# Altium schematic sheet Analog.SchDoc
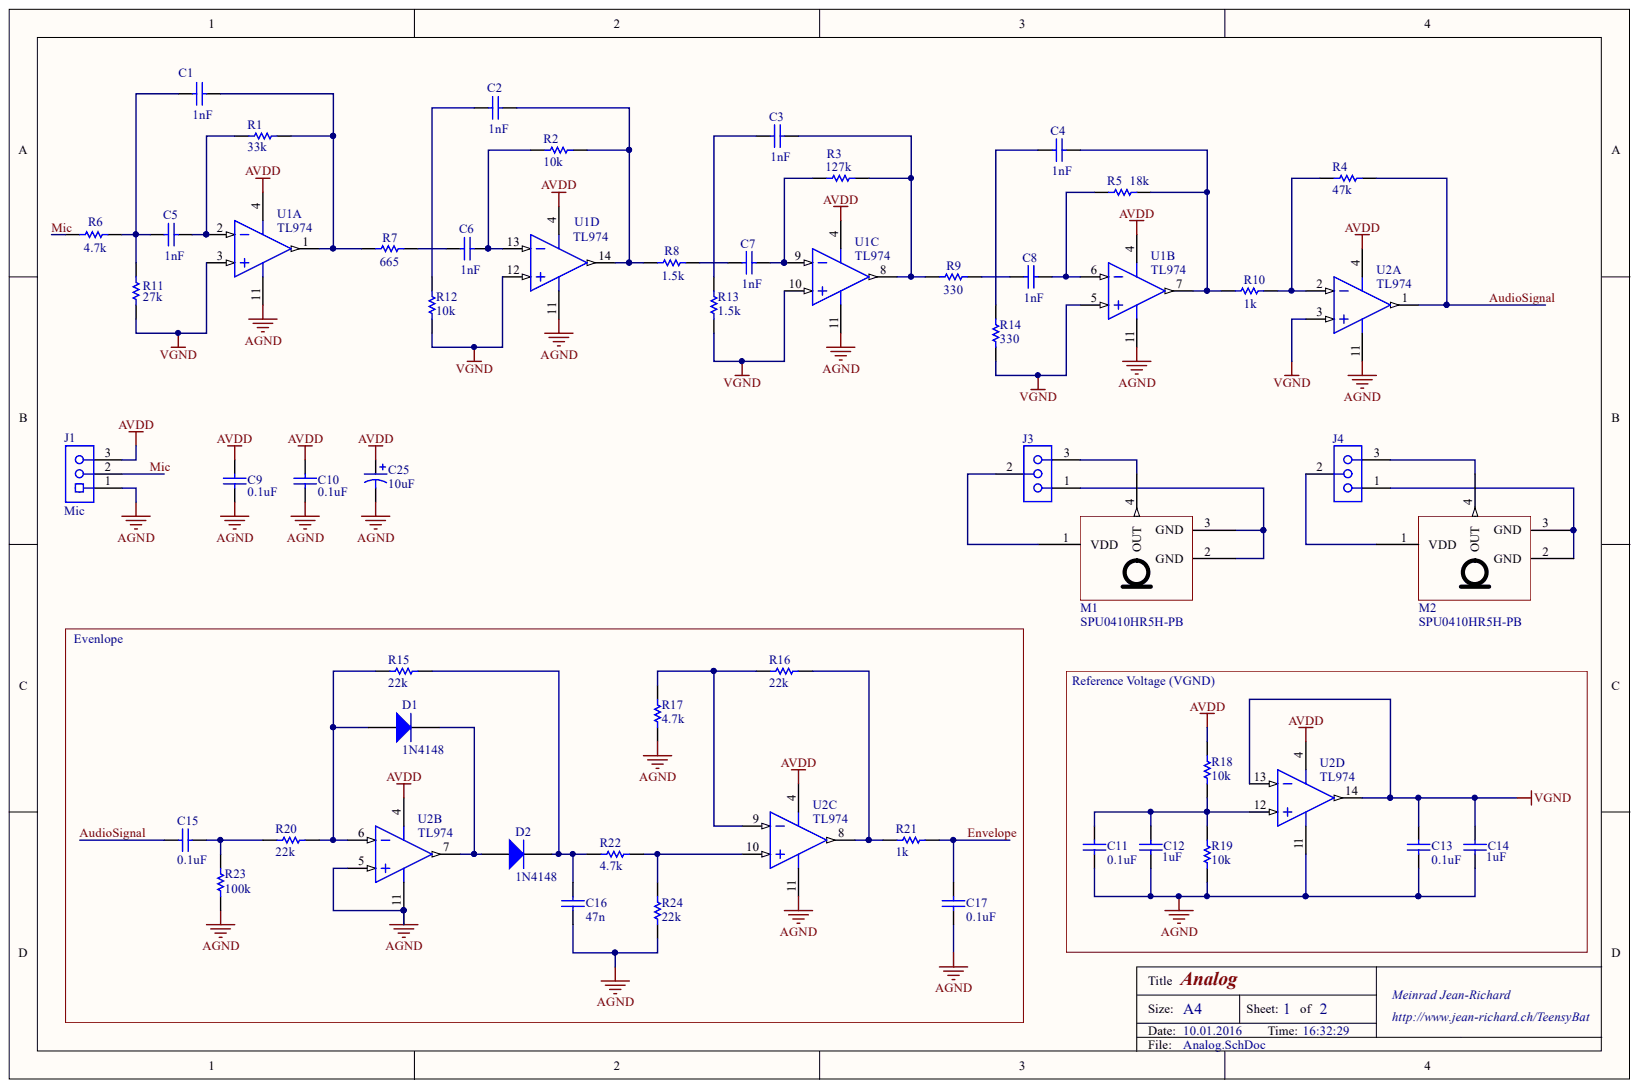
[diagram p0: Analog.SchDoc]

## Parts
C1  Capacitance: 1nF  [CAPC3216X94X50ML20T25]  (hidden comment: AVX Interconnect / Elco 12063A102JAT2A)
C2  Capacitance: 1nF  [CAPC3216X94X50ML20T25]  (hidden comment: AVX Interconnect / Elco 12063A102JAT2A)
C3  Capacitance: 1nF  [CAPC3216X94X50ML20T25]  (hidden comment: AVX Interconnect / Elco 12063A102JAT2A)
C4  Capacitance: 1nF  [CAPC3216X94X50ML20T25]  (hidden comment: AVX Interconnect / Elco 12063A102JAT2A)
C5  Capacitance: 1nF  [CAPC3216X94X50ML20T25]  (hidden comment: AVX Interconnect / Elco 12063A102JAT2A)
C6  Capacitance: 1nF  [CAPC3216X94X50ML20T25]  (hidden comment: AVX Interconnect / Elco 12063A102JAT2A)
C7  Capacitance: 1nF  [CAPC3216X94X50ML20T25]  (hidden comment: AVX Interconnect / Elco 12063A102JAT2A)
C8  Capacitance: 1nF  [CAPC3216X94X50ML20T25]  (hidden comment: AVX Interconnect / Elco 12063A102JAT2A)
C9  Capacitance: 0.1uF  [CAPC3216X94X50ML20T25]  (hidden comment: AVX Interconnect / Elco 12065C104KAT2A)
C10  Capacitance: 0.1uF  [CAPC3216X94X50ML20T25]  (hidden comment: AVX Interconnect / Elco 12065C104KAT2A)
C11  Capacitance: 0.1uF  [CAPC3216X94X50ML20T25]  (hidden comment: AVX Interconnect / Elco 12065C104KAT2A)
C12  Capacitance: 1uF  [CAPC3216X178X50ML20T25]  (hidden comment: AVX Interconnect / Elco 12065C105KAT2A)
C13  Capacitance: 0.1uF  [CAPC3216X94X50ML20T25]  (hidden comment: AVX Interconnect / Elco 12065C104KAT2A)
C14  Capacitance: 1uF  [CAPC3216X178X50ML20T25]  (hidden comment: AVX Interconnect / Elco 12065C105KAT2A)
C15  Capacitance: 0.1uF  [CAPC3216X94X50ML20T25]  (hidden comment: AVX Interconnect / Elco 12065C104KAT2A)
C16  47n  [CAPC3216X94X50ML20T25]
C17  Capacitance: 0.1uF  [CAPC3216X94X50ML20T25]  (hidden comment: AVX Interconnect / Elco 12065C104KAT2A)
C25  10uF  [PCB-w1mz1f6kibge3ckcia7t-1]
D1  1N4148  [DIOD-1N4148WS-SOD-323-2]
D2  1N4148  [DIOD-1N4148WS-SOD-323-2]
J1  Mic  [PCB-tsoe1m70tj06s3chv47k-1]
J3  TE Connectivity 825433-3  [PCB-43x6b8o6d5mxbq35c0sh-1]  (value not drawn)
J4  TE Connectivity 825433-3  [PCB-43x6b8o6d5mxbq35c0sh-1]  (value not drawn)
M1  SPU0410HR5H-PB  [Knowles-SPU0410HR5H]
M2  SPU0410HR5H-PB  [Knowles-SPU0410HR5H]
R1  Resistance: 33k  [RESC3216X70X50NL05T20]  (hidden comment: Panasonic ERJ-8ENF3302V)
R2  10k  [RESC3216X70X50NL05T20]
R3  Resistance: 127k  [RESC3216X70X50NL05T20]  (hidden comment: Panasonic ERJ-8ENF1273V)
R4  47k  [RESC3216X70X50NL05T20]
R5  Resistance: 18k  [RESC3216X70X50NL05T20]  (hidden comment: Panasonic ERJ-8ENF1802V)
R6  Resistance: 4.7k  [RESC3216X70X50NL05T20]  (hidden comment: Panasonic ERJ-8ENF4701V)
R7  Panasonic ERJ-8ENF6650V  [RESC3216X70X50NL05T20]  (value not drawn)
R8  Resistance: 1.5k  [RESC3216X70X50NL05T20]  (hidden comment: Panasonic ERJ-8ENF1501V)
R9  Panasonic ERJ-8ENF3300V  [RESC3216X70X50NL05T20]  (value not drawn)
R10  1k  [RESC3216X70X50NL05T20]
R11  Resistance: 27k  [RESC3216X70X50NL05T20]  (hidden comment: Panasonic ERJ8ENF2702V)
R12  10k  [RESC3216X70X50NL05T20]
R13  Resistance: 1.5k  [RESC3216X70X50NL05T20]  (hidden comment: Panasonic ERJ-8ENF1501V)
R14  Panasonic ERJ-8ENF3300V  [RESC3216X70X50NL05T20]  (value not drawn)
R15  22k  [RESC3216X70X50NL05T20]
R16  22k  [RESC3216X70X50NL05T20]
R17  4.7k  [RESC3216X70X50NL05T20]
R18  10k  [RESC3216X70X50NL05T20]
R19  10k  [RESC3216X70X50NL05T20]
R20  22k  [RESC3216X70X50NL05T20]
R21  1k  [RESC3216X70X50NL05T20]
R22  4.7k  [RESC3216X70X50NL05T20]
R23  100k  [RESC3216X70X50NL05T20]
R24  22k  [RESC3216X70X50NL05T20]
U1  TL974  [TI-D14_N]  (parts A,B,C,D)
U2  TL974  [TI-D14_N]  (parts A,B,C,D)

## Nets
AGND: C9.1 C10.1 C11.1 C12.1 C13.1 C14.1 C16.1 C17.1 C25.2 J1.1 R17.1 R19.2 R23.1 R24.1 U1.11 U2.5 U2.11
AVDD: C9.2 C10.2 C25.1 J1.3 R18.1 U1.4 U2.4
VGND: C13.2 C14.2 R11.1 R12.2 R13.1 R14.1 U1.3 U1.5 U1.10 U1.12(1nF) U2.3 U2.13 U2.14
AUDIOSIGNAL: C15.2 R4.2 U2.1
ENVELOPE: C17.2 R21.2
MIC: J1.2 R6.1
NetC1_1: C1.1 C5.1 R6.2 R11.2
NetC1_2: C1.2 R1.1 R7.1 U1.1
NetC2_1: C2.1 R12.1
NetC2_2: C2.2 R2.1 R8.1 U1.14
NetC3_1: C3.1 R13.2
NetC3_2: C3.2 R3.2 R9.1 U1.8
NetC4_1: C4.1 R14.2
NetC4_2: C4.2 R5.2 R10.1 U1.7
NetC5_2: C5.2 R1.2 U1.2
NetC6_1: C6.1 R7.2
NetC6_2: C6.2 R2.2 U1.13
NetC7_1: C7.1 R8.2
NetC7_2: C7.2 R3.1 U1.9
NetC8_1: C8.1 R9.2
NetC8_2: C8.2 R5.1 U1.6
NetC11_2: C11.2 C12.2 R18.2 R19.1 U2.12
NetC15_1: C15.1 R20.1 R23.2
NetC16_2: C16.2 D2.1 R15.2 R22.2
NetD1_1: D1.1 D2.2 U2.7
NetD1_2: D1.2 R15.1 R20.2 U2.6
NetJ3_1: J3.1 M1.2(GND) M1.3(GND)
NetJ3_2: J3.2 M1.1(VDD)
NetJ3_3: J3.3 M1.4(OUT)
NetJ4_1: J4.1 M2.2(GND) M2.3(GND)
NetJ4_2: J4.2 M2.1(VDD)
NetJ4_3: J4.3 M2.4(OUT)
NetR4_1: R4.1 R10.2 U2.2
NetR16_1: R16.1 R17.2 U2.9
NetR16_2: R16.2 R21.1 U2.8
NetR22_1: R22.1 R24.2 U2.10

## Library data of the parts
C1-C8 = CMP-173154-2: CAP CER 1000PF 25V 5% NP0 1206; Case/Package (SI): 3216; Dielectric Characteristic: C0G/NP0; Lead-Free Status: Lead Free; Voltage Rating (DC): 25.0 V; Capacitance Tolerance: ±5%; Case/Package: 1206; Component Kind: Standard; Library Name: CommunityVault; Mounting Style: Surface Mount; Number of Pins: 2; OctopartID: ed7b24f28dc832bc; Octopart Url: https://octopart.com/12063a102jat2a-avx+interconnect+%2F+elco-8603093; Packaging: Tape; RoHS: Compliant
C9-C11 C13 C15 C17 = CMP-126010-2: CAP CER 0.1UF 50V 10% X7R 1206; Case/Package (SI): 3216; Dielectric Material: Ceramic; Insulation Resistance: 1e+11 Ω; Lead-Free Status: Lead Free; Voltage Rating (DC): 50.0 V; Capacitance Tolerance: ±10%; Case/Package: 1206; Component Kind: Standard; Dielectric Characteristic: X7R; Library Name: CommunityVault; Mounting Style: Surface Mount; Number of Pins: 2; OctopartID: 839348c5bc2c5c54; Octopart Url: https://octopart.com/12065c104kat2a-avx+interconnect+%2F+elco-39590617; REACH SVHC Compliance: No SVHC; RoHS: Compliant; Size-Height: 0.00094 m; Size-Length: 0.0032004 m; Size-Thickness: 0.0009398 m; Size-Width: 0.0016002 m
C12 C14 = CMP-127284-2: CAP CER 1UF 50V 10% X7R 1206; Case/Package (SI): 3216; Dielectric Material: Ceramic Multilayer; Lead-Free Status: Lead Free; Voltage Rating (DC): 50.0 V; Capacitance Tolerance: ±10%; Case/Package: 1206; Component Kind: Standard; Dielectric Characteristic: X7R; Library Name: CommunityVault; Mounting Style: Surface Mount; Number of Pins: 2; OctopartID: 506d991562b08faa; Octopart Url: https://octopart.com/12065c105kat2a-avx+interconnect+%2F+elco-7477179; REACH SVHC Compliance: No SVHC; RoHS: Compliant
C16 = CMP-126512-2: CAP CER 0.047UF 50V 10% X7R 1206; Capacitance: 4.7e-08 F; Case/Package (SI): 3216; Dielectric Material: Ceramic Multilayer; Lead-Free Status: Lead Free; Voltage Rating (DC): 50.0 V; Capacitance Tolerance: ±10%; Case/Package: 1206; Component Kind: Standard; Dielectric Characteristic: X7R; Library Name: CommunityVault; Mounting Style: Surface Mount; Number of Pins: 2; OctopartID: 472a8c7d27a098ed; Octopart Url: https://octopart.com/12065c473kat2a-avx+interconnect+%2F+elco-39667180; REACH SVHC Compliance: No SVHC; RoHS: Compliant; Size-Height: 0.00094 m; Size-Length: 0.0032 m; Size-Width: 0.0016 m
C25 = CMP-295de054142df69a-1: 5mm x 11mm l/s=2.0mm 105deg 10uf 16v Radial Leads Lesr Aluminum Electrolytic Cap; Manufacturer Part Number: UPW1C100MDD; Capacitance: 1e-05 F; Capacitance Tolerance: ±20%; Equivalent Series Resistance (ESR): 1.2 Ω; Lead-Free Status: Lead Free; Voltage Rating (DC): 16.0 V; Component Kind: Standard; Dielectric Material: Aluminum Electrolytic; Library Name: CommunityVault; Mounting Style: Through Hole; Number of Pins: 2; OctopartID: 295de054142df69a; Octopart URL: http://octopart.com/upw1c100mdd-nichicon-202774; Packaging: Bulk; RoHS: Compliant; Size-Diameter: 0.005 m; Size-Height: 0.011 m; Size-Length: 0.011 m
D1 D2 = CMP-815266-2: DIODE SWITCHING 75V 0.15A SOD323; Capacitance: 4e-12 F; Current Rating: 0.2 A; Lead-Free Status: Lead Free; Polarity: Standard; Power Rating: 0.2 W; Voltage Rating (DC): 100.0 V; Case/Package: DO-35; Component Kind: Standard; Library Name: CommunityVault; Lifecycle Status: Active; Mounting Style: Through Hole; Number of Pins: 2; OctopartID: ae03670d61fd71c4; Octopart Url: https://octopart.com/1n4148-fairchild+semiconductor-322200; REACH SVHC Compliance: No SVHC; RoHS: Compliant
J1 = CMP-61f1a8aabfaebe36-2: M20 Socket Sil Vertical 3W 2.54Mm; Manufacturer Part Number: M20-7820346; Contact Resistance: 0.015 Ω; Current Rating: 3.0 A; Gender: Female; Insulation Resistance: 1000000.0 Ω; Number of Contacts: 3; Number of Mating Cycles: 50; Pin Pitch: 0.00254 m; Component Kind: Standard; Contact Material: Phosphor Bronze; Contact Plating: Tin; Library Name: CommunityVault; Number of Rows: 1; OctopartID: 61f1a8aabfaebe36; Octopart Url: http://octopart.com/m20-7820346-harwin-5372729; REACH SVHC Compliance: No SVHC; RoHS: Compliant; Seller Landing  Url: https://octopart-clicks.com/click/track?country=US&ak=8157f166&sig=097bad3&sid=2402&ppid=5372729&vpid=6626761&ct=offers
J3 J4 = CMP-52d299a8d8748d15-2: Pin header; pin strips; AMPMODU MOD II; male; PIN: 3; straight; Current Rating: 3.0 A; ELV Compliant: Compliant; Number of Contacts: 3; Pin Pitch: 0.00254 m; Component Kind: Standard; Contact Material: Brass; Contact Plating: Gold, Gold over Nickel, Tin, Tin over Nickel; Flammability Rating: UL 94 V-0; Gender: Male; Housing Color: Green; Housing Material: Polyester; Library Name: CommunityVault; Lifecycle Status: Active; Manufacturer Part Number: 825433-3; Mounting Style: PCB; OctopartID: 52d299a8d8748d15; Octopart URL: http://octopart.com/825433-3-te+connectivity-40939456; Orientation: Vertical; Packaging: Box; REACH SVHC Compliance: No SVHC; RoHS: Compliant
M1 M2 = CMP-554d9d6504ba8a8a-4: Mic Mems Analog Omni -42db; Supply Voltage (DC): V; Component Kind: Standard; Library Name: CommunityVault; Manufacturer Part Number: SPU0410HR5H-PB; OctopartID: 554d9d6504ba8a8a; Octopart URL: http://octopart.com/spu0410hr5h-pb-knowles+acoustics-19035281; RoHS: Compliant
R1 = CMP-3d14f753aa60e2d3-1: Res Thick Film 1206 33K Ohm 1% 1/4W ±100ppm/°C Molded SMD SMD Punched Carrier T/R; Power Rating: 0.25 W; Voltage Rating (DC): 200.0 V; Case/Package: 1206; Component Kind: Standard; Library Name: CommunityVault; Lifecycle Status: Not Listed by Manufacturer; Manufacturer Part Number: ERJ-8ENF3302V; Mounting Style: Surface Mount; Number of Pins: 2; OctopartID: 3d14f753aa60e2d3; Octopart URL: http://octopart.com/erj-8enf3302v-panasonic-55423506; Packaging: Tape & Reel (TR), Cut Tape (CT); REACH SVHC Compliance: No SVHC; Resistance Tolerance: ±1%; RoHS: Compliant
R2 R12 R18 R19 = CMP-3663449-2: Thick Film Resistors - SMD 1206 10Kohms 1% Tol; Case/Package (SI): 3216; Lead-Free Status: Lead Free; Power Rating: 0.25 W; Resistance: 10000.0 Ω; Voltage Rating (DC): 200.0 V; Case/Package: 1206; Component Kind: Standard; Library Name: CommunityVault; Lifecycle Status: Not Listed by Manufacturer; Mounting Style: Surface Mount; Number of Pins: 2; OctopartID: 5d4197061c908286; Octopart Url: https://octopart.com/erj-8enf1002v-panasonic-55403703; REACH SVHC Compliance: No SVHC; Resistance Tolerance: ±1%; RoHS: Compliant; Size-Height: 0.0006 m; Size-Length: 0.002 m; Size-Width: 0.0016 m
R3 = CMP-3679732-2: Thick Film Resistors 1206 127Kohms 1% Tolerance; Case/Package (SI): 3216; Power Rating: 0.25 W; Voltage Rating (DC): 200.0 V; Case/Package: 1206; Component Kind: Standard; Library Name: CommunityVault; Lifecycle Status: Not Listed by Manufacturer; Mounting Style: Surface Mount; OctopartID: 2e2ce46bba5af1eb; Octopart Url: https://octopart.com/erj-8enf1273v-panasonic-55423248; REACH SVHC Compliance: No SVHC; Resistance Tolerance: ±1%; RoHS: Compliant; Size-Height: 0.0006 m; Size-Length: 0.002 m; Size-Width: 0.0016 m
R4 = CMP-8a94435db0625553-1: Res Thick Film 1206 47K Ohm 1% 0.25W(1/4W) ±100ppm/C Molded SMD Automotive Punched T/R; Manufacturer Part Number: ERJ-8ENF4702V; Lead-Free Status: Lead Free; Resistance: 47000.0 Ω; Voltage Rating (DC): 200.0 V; Case/Package: 1206; Component Kind: Standard; Library Name: CommunityVault; Lifecycle Status: Not Listed by Manufacturer; Mounting Style: Surface Mount; Number of Pins: 2; OctopartID: 8a94435db0625553; Octopart URL: http://octopart.com/erj-8enf4702v-panasonic-12787600; Packaging: Tape & Reel (TR), Cut Tape (CT); Power Rating: 0.25 W; REACH SVHC Compliance: No SVHC; Resistance Tolerance: ±1%; RoHS: Compliant
R5 = CMP-8a3a407d1e2d55fd-1: RES, THICK FILM, 18K, 250mW, 1%, 1206, RES, THICK FILM, 18K, 250mW, 1%, 1206, FULL REEL; Power Rating: 0.25 W; Voltage Rating (DC): 200.0 V; Case/Package: 1206; Component Kind: Standard; Library Name: CommunityVault; Lifecycle Status: Not Listed by Manufacturer; Manufacturer Part Number: ERJ-8ENF1802V; Mounting Style: Surface Mount; Number of Pins: 2; OctopartID: 8a3a407d1e2d55fd; Octopart URL: http://octopart.com/erj-8enf1802v-panasonic-55423345; Packaging: Tape & Reel (TR), Cut Tape (CT); REACH SVHC Compliance: No SVHC; Resistance Tolerance: ±1%; RoHS: Compliant
R6 = CMP-e840219124d23787-1: Res Thick Film 1206 4.7K Ohm 1% 0.25W(1/4W) ±100ppm/C Molded SMD Automotive Punched T/R; Lead-Free Status: Lead Free; Voltage Rating (DC): 200.0 V; Case/Package: 1206; Component Kind: Standard; Library Name: CommunityVault; Lifecycle Status: Not Listed by Manufacturer; Manufacturer Part Number: ERJ-8ENF4701V; Mounting Style: Surface Mount; Number of Pins: 2; OctopartID: e840219124d23787; Octopart URL: http://octopart.com/erj-8enf4701v-panasonic-14412276; Packaging: Tape & Reel (TR), Cut Tape (CT); Power Rating: 0.25 W; REACH SVHC Compliance: No SVHC; Resistance Tolerance: ±1%; RoHS: Compliant
R7 = CMP-3676928-2: Thick Film Resistors - SMD 1206 665ohms 1% Tolerance; Case/Package (SI): 3216; Lead-Free Status: Contains Lead; Power Rating: 0.25 W; Resistance: 665; Voltage Rating (DC): 200.0 V; Case/Package: 1206; Component Kind: Standard; Library Name: CommunityVault; Lifecycle Status: Not Listed by Manufacturer; Mounting Style: Surface Mount; OctopartID: 11b5dbf2860ace72; Octopart Url: https://octopart.com/erj-8enf6650v-panasonic-55423705; REACH SVHC Compliance: No SVHC; Resistance Tolerance: ±1%; RoHS: Compliant; Size-Height: 0.0006 m; Size-Length: 0.002 m; Size-Width: 0.0016 m
R8 R13 = CMP-3653427-2: Thick Film Resistors - SMD 1206 1.5Kohms 1% Tol; Case/Package (SI): 3216; Lead-Free Status: Lead Free; Power Rating: 0.25 W; Voltage Rating (DC): 200.0 V; Case/Package: 1206; Component Kind: Standard; Library Name: CommunityVault; Lifecycle Status: Not Listed by Manufacturer; Mounting Style: Surface Mount; Number of Pins: 2; OctopartID: a81662513c4e77d1; Octopart Url: https://octopart.com/erj-8enf1501v-panasonic-55423294; REACH SVHC Compliance: No SVHC; Resistance Tolerance: ±1%; RoHS: Compliant; Size-Height: 0.0006 m; Size-Length: 0.002 m; Size-Width: 0.0016 m
R9 R14 = CMP-0560cc0afb7adaa7-1: Res Thick Film 1206 330 Ohm 1% 1/4W ±100ppm/°C Molded SMD SMD Punched Carrier T/R; Power Rating: 0.25 W; Resistance: 330; Voltage Rating (DC): 200.0 V; Case/Package: 1206; Component Kind: Standard; Library Name: CommunityVault; Lifecycle Status: Not Listed by Manufacturer; Manufacturer Part Number: ERJ-8ENF3300V; Mounting Style: Surface Mount; Number of Pins: 2; OctopartID: 0560cc0afb7adaa7; Octopart URL: http://octopart.com/erj-8enf3300v-panasonic-6347644; Packaging: Tape & Reel (TR), Cut Tape (CT); REACH SVHC Compliance: No SVHC; Resistance Tolerance: ±1%; RoHS: Compliant
R10 R21 = CMP-3663831-2: Thick Film Resistors - SMD 1206 1Kohms 1% Tolerance; Case/Package (SI): 3216; Lead-Free Status: Lead Free; Power Rating: 0.25 W; Resistance: 1000.0 Ω; Voltage Rating (DC): 200.0 V; Case/Package: 1206; Component Kind: Standard; Library Name: CommunityVault; Lifecycle Status: Not Listed by Manufacturer; Mounting Style: Surface Mount; Number of Pins: 2; OctopartID: 229be8dcb721fd5c; Octopart Url: https://octopart.com/erj-8enf1001v-panasonic-55423196; REACH SVHC Compliance: No SVHC; Resistance Tolerance: ±1%; RoHS: Compliant; Size-Height: 0.0006 m; Size-Length: 0.002 m; Size-Width: 0.0016 m
R11 = CMP-3986405-2: RESISTOR, 1206, 0.25W, 1%, 27K; Voltage Rating (DC): 200.0 V; Case/Package: 1206; Component Kind: Standard; Library Name: CommunityVault; Lifecycle Status: Not Listed by Manufacturer; Mounting Style: Surface Mount; Number of Pins: 2; OctopartID: 0f91c41c9dc4d056; Octopart Url: https://octopart.com/erj8enf2702v-panasonic-42448691; Power Rating: 0.25 W; REACH SVHC Compliance: No SVHC; Resistance Tolerance: ±1%; RoHS: Compliant
R15 R16 R20 R24 = CMP-b4347da146596bf3-2: Res Thick Film 1206 22K Ohm 1% 1/4W ±100ppm/°C Molded SMD SMD Punched Carrier T/R; Manufacturer Part Number: ERJ-8ENF2202V; Power Rating: 0.25 W; Resistance: 22000.0 Ω; Voltage Rating (DC): 200.0 V; Case/Package: 1206; Component Kind: Standard; Library Name: CommunityVault; Lifecycle Status: Not Listed by Manufacturer; Mounting Style: Surface Mount; Number of Pins: 2; OctopartID: b4347da146596bf3; Octopart URL: http://octopart.com/erj-8enf2202v-panasonic-10475275; Packaging: Tape & Reel (TR), Cut Tape (CT); REACH SVHC Compliance: No SVHC; Resistance Tolerance: ±1%; RoHS: Compliant
R17 R22 = CMP-e840219124d23787-1: Res Thick Film 1206 4.7K Ohm 1% 0.25W(1/4W) ±100ppm/C Molded SMD Automotive Punched T/R; Manufacturer Part Number: ERJ-8ENF4701V; Lead-Free Status: Lead Free; Resistance: 4700.0 Ω; Voltage Rating (DC): 200.0 V; Case/Package: 1206; Component Kind: Standard; Library Name: CommunityVault; Lifecycle Status: Not Listed by Manufacturer; Mounting Style: Surface Mount; Number of Pins: 2; OctopartID: e840219124d23787; Octopart URL: http://octopart.com/erj-8enf4701v-panasonic-14412276; Packaging: Tape & Reel (TR), Cut Tape (CT); Power Rating: 0.25 W; REACH SVHC Compliance: No SVHC; Resistance Tolerance: ±1%; RoHS: Compliant
R23 = CMP-3656152-2: Thick Film Resistors - SMD 1206 100Kohms 1% Tolerance; Case/Package (SI): 3216; Lead-Free Status: Lead Free; Power Rating: 0.25 W; Resistance: 100000.0 Ω; Voltage Rating (DC): 200.0 V; Case/Package: 1206; Component Kind: Standard; Library Name: CommunityVault; Lifecycle Status: Not Listed by Manufacturer; Mounting Style: Surface Mount; Number of Pins: 2; OctopartID: 5cd296951d0c8315; Octopart Url: https://octopart.com/erj-8enf1003v-panasonic-55403704; REACH SVHC Compliance: No SVHC; Resistance Tolerance: ±1%; RoHS: Compliant; Size-Height: 0.0006 m; Size-Length: 0.002 m; Size-Width: 0.0016 m
U1 U2 = CMP-1321322-2: Output Rail-To-Rail Very-Low-Noise Operational Amplifier, 2.7 to 12 V, -40 to 125 degC, 14-pin SOIC (D14), Green (RoHS & no Sb/Br); Bandwidth: 12000000.0 Hz; Input Offset Drift: 5e-06 V/K; Lead-Free Status: Lead Free; Number of Channels: 4; Slew Rate: 5.0 V/μs; Component Kind: Standard; Gain Bandwidth Product: 12000000.0 Hz; Library Name: CommunityVault; Lifecycle Status: Active; Number of Pins: 14; OctopartID: 14b2ddeb11e6cb75; Octopart Url: https://octopart.com/tl974idr-texas+instruments-5447730; Packaging: Tape & Reel (TR); RoHS: Compliant
